# Altium schematic sheet power.SchDoc
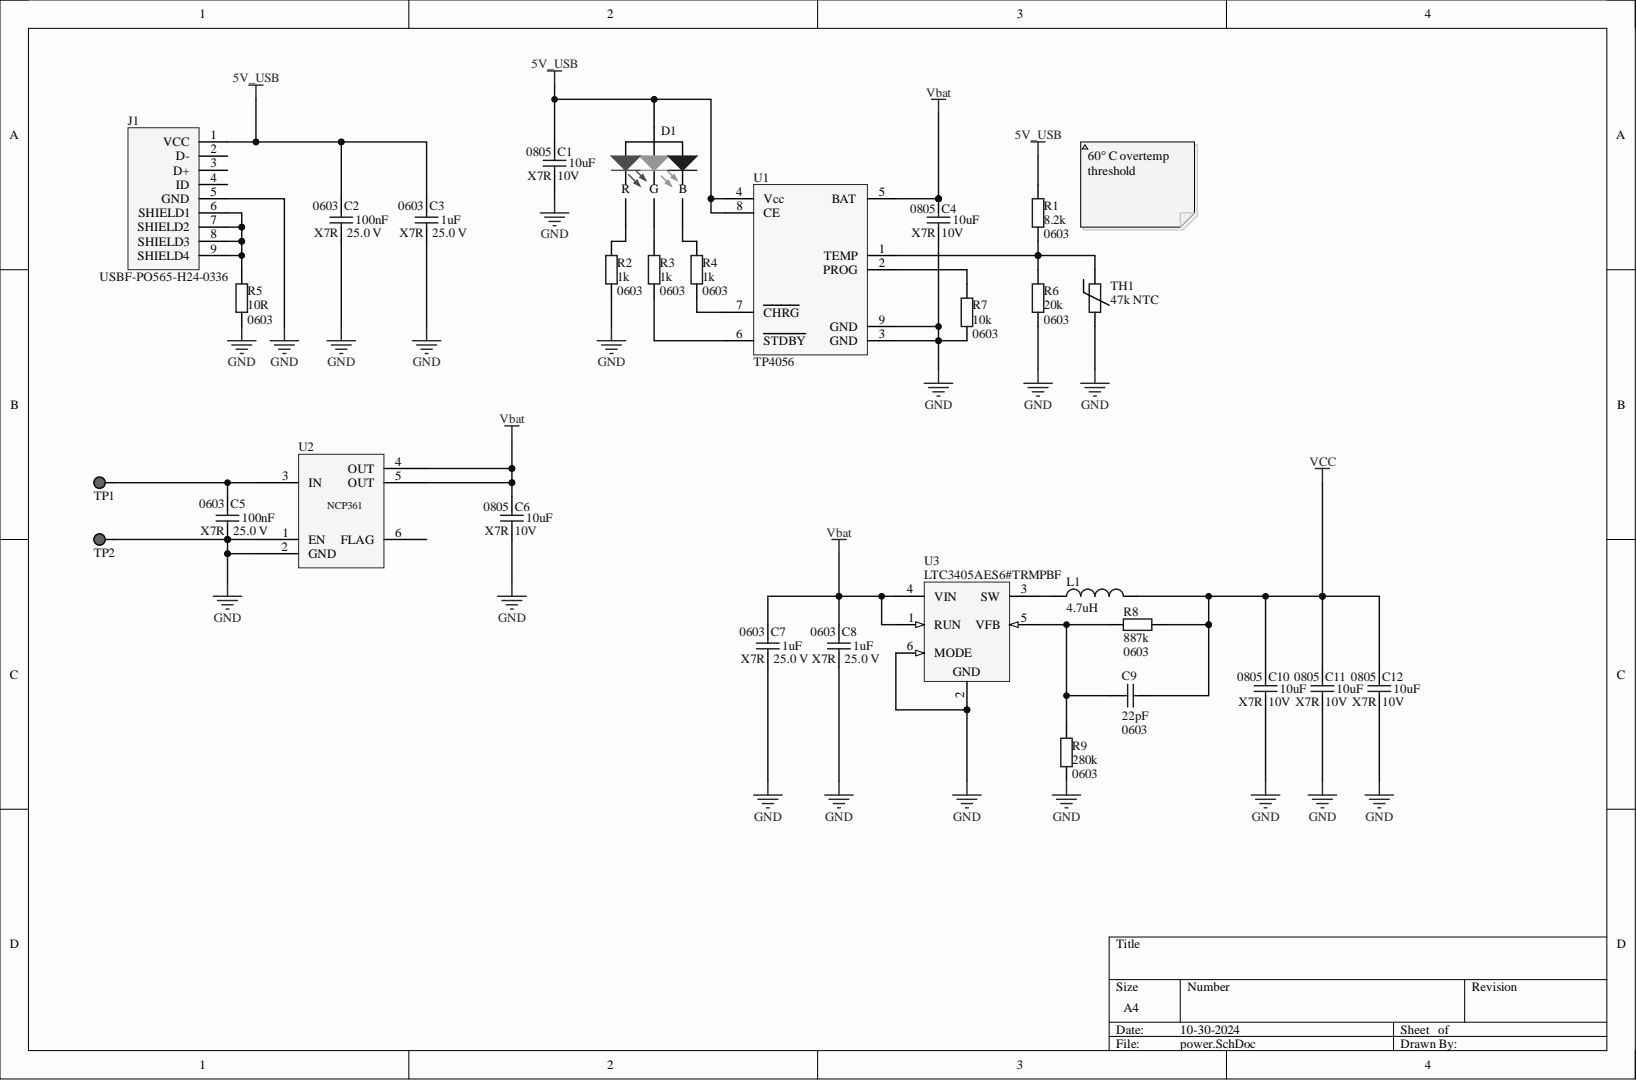
[diagram p0: power.SchDoc]

## Parts
C1  Capacitance: 10uF  Case/Package (SI): 0805  Dielectric Characteristic: X7R  Voltage Rating (DC): 10V  [CAPC2013X94X50LL20T25]
C2  Capacitance: 100nF  Case/Package: 0603  Dielectric Characteristic: X7R  Voltage Rating (DC): 25.0 V  [CAPC1608X90X35LL15T15]
C3  Capacitance: 1uF  Case/Package: 0603  Dielectric Characteristic: X7R  Voltage Rating (DC): 25.0 V  [CAPC1608X90X35LL15T15]
C4  Capacitance: 10uF  Case/Package (SI): 0805  Dielectric Characteristic: X7R  Voltage Rating (DC): 10V  [CAPC2013X94X50LL20T25]
C5  Capacitance: 100nF  Case/Package: 0603  Dielectric Characteristic: X7R  [CAPC1608X90X35LL15T15]
C6  Capacitance: 10uF  Case/Package (SI): 0805  Dielectric Characteristic: X7R  Voltage Rating (DC): 10V  [CAPC2013X94X50LL20T25]
C7  Capacitance: 1uF  Case/Package: 0603  Dielectric Characteristic: X7R  [CAPC1608X90X35LL15T15]
C8  Capacitance: 1uF  Case/Package: 0603  Dielectric Characteristic: X7R  Voltage Rating (DC): 25.0 V  [CAPC1608X90X35LL15T15]
C9  Capacitance: 22pF  Case/Package: 0603  [CAPC1608X90X35LL15T15]
C10  Capacitance: 10uF  Case/Package (SI): 0805  Dielectric Characteristic: X7R  Voltage Rating (DC): 10V  [CAPC2013X94X50LL20T25]
C11  Capacitance: 10uF  Case/Package (SI): 0805  Dielectric Characteristic: X7R  Voltage Rating (DC): 10V  [CAPC2013X94X50LL20T25]
C12  Capacitance: 10uF  Case/Package (SI): 0805  Dielectric Characteristic: X7R  Voltage Rating (DC): 10V  [CAPC2013X94X50LL20T25]
D1  Led RGB  [PCB-5x94mfie5525cac7h9me-1]  (value not drawn)
J1  USBF-PO565-H24-0336  [PCB-2l75tl1wroewix9zrd2j-1]
L1  4.7uH  [PCB-zgco03nc205dkp7r2ws6-1]
R1  Resistance: 8.2k  [0603]
R2  Resistance: 1k  [0603]
R3  Resistance: 1k  [0603]
R4  Resistance: 1k  [0603]
R5  Resistance: 10R  [0603]
R6  Resistance: 20k  [0603]
R7  Resistance: 10k  [0603]
R8  Resistance: 887k  [0603]
R9  Resistance: 280k  [0603]
TH1  47k NTC  [PCB-e9ii8bi6lzz9dnzpkoei-1]
TP1  SYM-vpgufk0wj0n7691111o4-1  [PCB-covcsa37iay0q2z63tsu-1]  (value not drawn)
TP2  SYM-vpgufk0wj0n7691111o4-1  [PCB-covcsa37iay0q2z63tsu-1]  (value not drawn)
U1  TP4056  [SOP8_H]
U2  Semiconductor NCP361SNT1G  [TSOP-5_H]  (value not drawn)
U3  LTC3405AES6#TRMPBF  [LT-S6-6-TSOT-23_N]

## Nets
5V_USB: C1.2 C2.2 C3.2 D1.4 J1.1(VCC) R1.2 U1.4(Vcc) U1.8(CE)
GND: C1.1 C2.1 C3.1 C4.1 C5.1 C6.1 C7.1 C8.1 C10.1 C11.1 C12.1 J1.5(GND) R2.1 R5.1 R6.1 R7.1 R9.1 TH1.1 TP2.1 U1.3(GND) U1.9(GND) U2.1(EN) U2.2(GND) U3.2 U3.6(MODE)
Vbat: C4.2 C6.2 C7.2 C8.2 U1.5(BAT) U2.4(OUT) U2.5(OUT) U3.1(RUN) U3.4(VIN)
VCC: C9.1 C10.2 C11.2 C12.2 L1.2 R8.2
NetC5_2: C5.2 TP1.1 U2.3(IN)
NetC9_2: C9.2 R8.1 R9.2 U3.5(VFB)
NetD1_1: D1.1(R) R2.2
NetD1_2: D1.2(G) R3.2
NetD1_3: D1.3(B) R4.2
NetJ1_6: J1.6(SHIELD1) J1.7(SHIELD2) J1.8(SHIELD3) J1.9(SHIELD4) R5.2
NetL1_1: L1.1 U3.3(SW)
NetR1_1: R1.1 R6.2 TH1.2 U1.1(TEMP)
NetR3_1: R3.1 U1.6(STDBY)
NetR4_1: R4.1 U1.7(CHRG)
NetR7_2: R7.2 U1.2(PROG)

Not connected: J1 2(D-) 3(D+) 4(ID); U2 6(FLAG)

## Library data of the parts
C1 C4 C6 C10-C12 = CMP-126073-3: CAP CER 2200PF 50V 10% X7R 0805; Capacitance Tolerance: ±10%; Component Kind: Standard; Library Name: CommunityVault
C2 C3 C8 = CMP-126693-2: CAP CER 0.1UF 25V 10% X7R 0603; Capacitance Tolerance: ±10%; Component Kind: Standard; Library Name: CommunityVault
C5 C7 = CMP-126693-2: CAP CER 0.1UF 25V 10% X7R 0603; Voltage Rating (DC): 25.0 V; Capacitance Tolerance: ±10%; Component Kind: Standard; Library Name: CommunityVault
C9 = CMP-126693-2: CAP CER 0.1UF 25V 10% X7R 0603; Dielectric Characteristic: C0G; Voltage Rating (DC): 50V; Capacitance Tolerance: ±10%; Component Kind: Standard; Library Name: CommunityVault
D1 = CMP-eotsd02ujfoltwjxkvzh-1: 1.6mm*1.6mm*0.55mm,0606; Component Kind: Standard; CustomComponentID: eotsd02ujfoltwjxkvzh; Library Name: FavoritesLibrary
J1 = CMP-0872zdfeloch3th6xfjo-1: Micro USB B Female 5pin connector; Component Kind: Standard; CustomComponentID: 0872zdfeloch3th6xfjo; Library Name: FavoritesLibrary
L1 = CMP-22snfcfb5eszgd5owwah-1: SMD power inductor CD32 series; Manufacturer: Shuo Xing; Component Kind: Standard; CustomComponentID: 22snfcfb5eszgd5owwah; Library Name: CommunityVault
R1-R9 = CMP-8f45450282185082-2: Resistance Tolerance: ±5%; Component Kind: Standard; Library Name: CommunityVault; Package: 0603
TH1 = CMP-7ae9b3e83e3aa52e-1: 47 kOhm NTC; Manufacturer Part Number: NCP18WB473J03RB; Case/Package (SI): 1608; Lead-Free Status: Lead Free; Resistance: 47.0 k?; Resistance Tolerance: ±5%; Case/Package: 0603; Component Kind: Standard; Library Name: CommunityVault; Mounting Style: Surface Mount; Number of Pins: 2; Octopart URL: http://octopart.com/ncp18wb473j03rb-murata-745909; OctopartID: 7ae9b3e83e3aa52e; Packaging: Cut Tape (CT), Tape & Reel (TR); REACH SVHC Compliance: No SVHC; RoHS: Compliant; Size-Length: 1.60 mm; Size-Thickness: 150 µm; Size-Width: 800 µm
TP1 TP2 = CMP-vpgufk0wj0n7691111o4-2: 1mm round testpoint; Component Kind: Standard; CustomComponentID: vpgufk0wj0n7691111o4; Library Name: CommunityVault
U1 = CMP-abte730zfbn217gb2l28-3: 1A Standalone Linear Li-Ion Battery Charger; Component Kind: Standard; Library Name: CommunityVault; Manufacturer Part Number: TP4056
U2 = CMP-9dda54aec9fd421d-2: Overvoltage Protection Circuit, USB Positive, with Internal PMOS FET and Overcurrent Protection OVLO 5.675 V, OCP 750 mA,TSOP-5; Lead-Free Status: Lead Free; Supply Current: 20.0 µA; Supply Voltage (DC): 1.20 V (min); Component Kind: Standard; Halogen Free Status: Halogen Free; Library Name: FavoritesLibrary; Lifecycle Status: Active; Manufacturer Part Number: NCP361SNT1G; Number of Pins: 5; Octopart URL: http://octopart.com/ncp361snt1g-on+semiconductor-8286529; OctopartID: 9dda54aec9fd421d; Packaging: Cut Tape (CT); REACH SVHC Compliance: No SVHC; RoHS: Compliant
U3 = CMP-1482502-2: 1.5 MHz, 300 mA Synchronous Step-Down Regulator, 6-pin SOT23 (S6-6), -40 to 85 degC, Pb-Free, Mini Tape and Reel; Lead-Free Status: Lead Free; Number of Outputs: 1; Output Current: 0.3 A; Case/Package: SOT-23; Component Kind: Standard; Library Name: CommunityVault; Mounting Style: Surface Mount; Number of Pins: 6; Octopart Url: https://octopart.com/ltc3405aes6%23trmpbf-linear+technology-534801; OctopartID: bb967294b7e16451; Packaging: Cut Tape (CT); RoHS: Compliant
